# Altium schematic sheet MainBoard.SchDoc
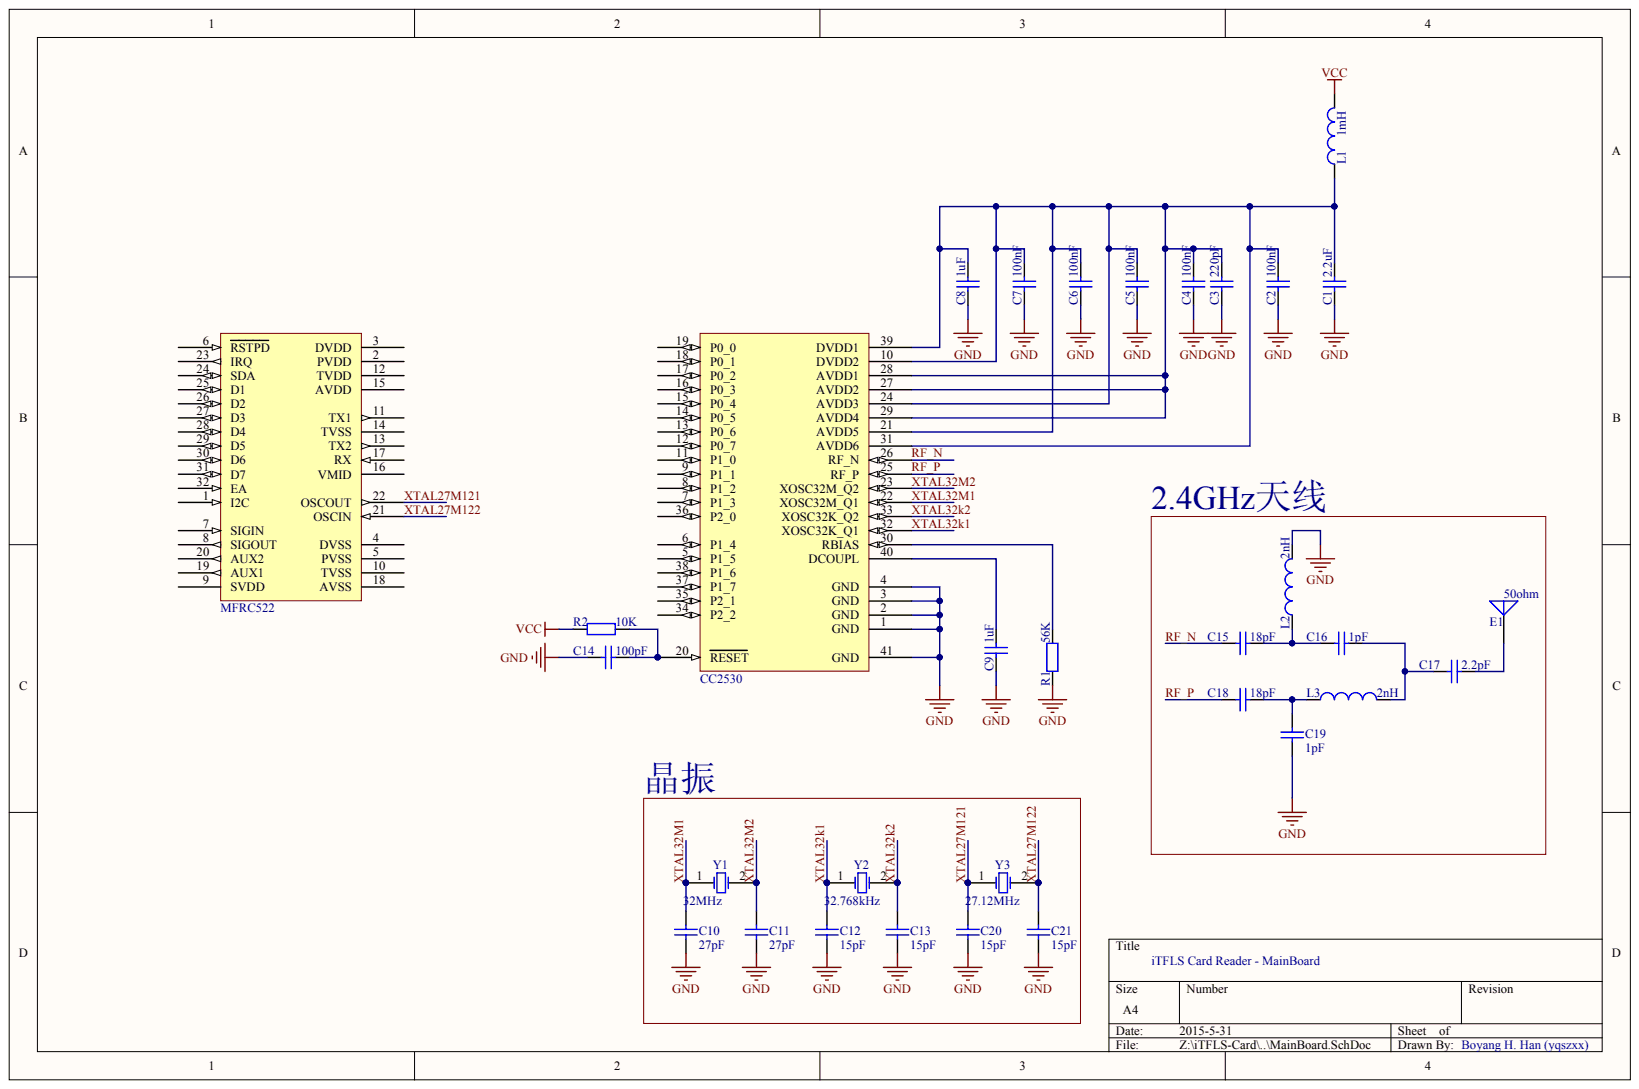
[diagram p0: MainBoard.SchDoc]

## Parts
1  CC2530
2  MFRC522
C1  value 2.2uF  [RAD-0.3]  (hidden comment: Cap)
C2  value 100nF  [RAD-0.3]  (hidden comment: Cap)
C3  value 220pF  [RAD-0.3]  (hidden comment: Cap)
C4  value 100nF  [RAD-0.3]  (hidden comment: Cap)
C5  value 100nF  [RAD-0.3]  (hidden comment: Cap)
C6  value 100nF  [RAD-0.3]  (hidden comment: Cap)
C7  value 100nF  [RAD-0.3]  (hidden comment: Cap)
C8  value 1uF  [RAD-0.3]  (hidden comment: Cap)
C9  value 1uF  [RAD-0.3]  (hidden comment: Cap)
C10  value 27pF  [RAD-0.3]  (hidden comment: Cap)
C11  value 27pF  [RAD-0.3]  (hidden comment: Cap)
C12  value 15pF  [RAD-0.3]  (hidden comment: Cap)
C13  value 15pF  [RAD-0.3]  (hidden comment: Cap)
C14  value 100pF  [RAD-0.3]  (hidden comment: Cap)
C15  value 18pF  [RAD-0.3]  (hidden comment: Cap)
C16  value 1pF  [RAD-0.3]  (hidden comment: Cap)
C17  value 2.2pF  [RAD-0.3]  (hidden comment: Cap)
C18  value 18pF  [RAD-0.3]  (hidden comment: Cap)
C19  value 1pF  [RAD-0.3]  (hidden comment: Cap)
C20  value 15pF  [RAD-0.3]  (hidden comment: Cap)
C21  value 15pF  [RAD-0.3]  (hidden comment: Cap)
E1  value 50ohm  [PIN1]  (hidden comment: Antenna)
L1  value 1mH  [0402-A]  (hidden comment: Inductor)
L2  value 2nH  [0402-A]  (hidden comment: Inductor)
L3  value 2nH  [0402-A]  (hidden comment: Inductor)
R1  value 56K  [AXIAL-0.4]  (hidden comment: Res2)
R2  value 10K  [AXIAL-0.4]  (hidden comment: Res2)
Y1  XTAL  value 32MHz  [R38]
Y2  XTAL  value 32.768kHz  [R38]
Y3  XTAL  value 27.12MHz  [R38]

## Nets
GND: 1.1(GND) 1.2(GND) 1.3(GND) 1.4(GND) 1.41(GND) C1.1 C2.1 C3.1 C4.1 C5.1 C6.1 C7.1 C8.1 C9.1 C10.1 C11.1 C12.1 C13.1 C14.1 C19.1 C20.1 C21.1 L2.2 R1.1
VCC: L1.2 R2.1
RF_N: 1.26(RF_N) C15.1
RF_P: 1.25(RF_P) C18.1
XTAL27M121: 2.22(OSCOUT) C20.2 Y3.1
XTAL27M122: 2.21(OSCIN) C21.2 Y3.2
XTAL32K1: 1.32(XOSC32K_Q1) C12.2 Y2.1
XTAL32K2: 1.33(XOSC32K_Q2) C13.2 Y2.2
XTAL32M1: 1.22(XOSC32M_Q1) C10.2 Y1.1
XTAL32M2: 1.23(XOSC32M_Q2) C11.2 Y1.2
Net1_10: 1.10(DVDD2) 1.21(AVDD5) 1.24(AVDD3) 1.27(AVDD2) 1.28(AVDD1) 1.29(AVDD4) 1.31(AVDD6) 1.39(DVDD1) C1.2 C2.2 C3.2 C4.2 C5.2 C6.2 C7.2 C8.2 L1.1
Net1_20: 1.20(RESET) C14.2 R2.2
Net1_30: 1.30(RBIAS) R1.2
Net1_40: 1.40(DCOUPL) C9.2
NetC15_2: C15.2 C16.1 L2.1
NetC16_2: C16.2 C17.1 L3.2
NetC17_2: C17.2 E1.1
NetC18_2: C18.2 C19.2 L3.1

Not connected: 1 5(P1_5) 6(P1_4) 7(P1_3) 8(P1_2) 9(P1_1) 11(P1_0) 12(P0_7) 13(P0_6) 14(P0_5) 15(P0_4) 16(P0_3) 17(P0_2) 18(P0_1) 19(P0_0) 34(P2_2) 35(P2_1) 36(P2_0) 37(P1_7) 38(P1_6); 2 1(I2C) 2(PVDD) 3(DVDD) 4(DVSS) 5(PVSS) 6(RSTPD) 7(SIGIN) 8(SIGOUT) 9(SVDD) 10(TVSS) 11(TX1) 12(TVDD) 13(TX2) 14(TVSS) 15(AVDD) 16(VMID) 17(RX) 18(AVSS) 19(AUX1) 20(AUX2) 23(IRQ) 24(SDA) 25(D1) 26(D2) 27(D3) 28(D4) 29(D5) 30(D6) 31(D7) 32(EA)

## Library data of the parts
C1-C21 = Cap: Capacitor; Component Kind: Standard; LatestRevisionDate: 17-Jul-2002; LatestRevisionNote: Re-released for DXP Platform.; Library Name: Miscellaneous Devices.IntLib; PackageDescription: Radial Cap, Thru-Hole; 2 Leads; 0.3 in Pin Spacing; PackageReference: RAD-0.3; Published: 8-Jun-2000; Signal Integrity: Cap; Simulation: CAP; Publisher: Altium Limited
E1 = Antenna: Generic Antenna; Component Kind: Standard; LatestRevisionDate: 17-Jul-2002; LatestRevisionNote: Re-released for DXP Platform.; Library Name: Miscellaneous Devices.IntLib; PackageReference: PIN1; Published: 24-Mar-1999; Publisher: Altium Limited
L1-L3 = Inductor: Code_IEC: 1005; Code_JEITA: 0402; Component Kind: Standard; Description: Inductor; LatestRevisionDate: 29-May-2009; LatestRevisionNote: IPC-7351 Footprint Added.; Library Name: Miscellaneous Devices.IntLib; PackageDescription: Chip Inductor; PackageReference: 0402-A; Published: 8-Jun-2000; Signal Integrity: Inductor; Simulation: INDUCTOR; Publisher: Altium Limited
R1 R2 = Res2: Resistor; Component Kind: Standard; LatestRevisionDate: 17-Jul-2002; LatestRevisionNote: Re-released for DXP Platform.; Library Name: Miscellaneous Devices.IntLib; PackageDescription: Axial Device, Thru-Hole; 2 Leads; 0.4 in Pin Spacing; PackageReference: AXIAL-0.4; Published: 8-Jun-2000; Signal Integrity: Res; Simulation: RESISTOR; Publisher: Altium Limited
Y1-Y3 = XTAL: Crystal Oscillator; Component Kind: Standard; LatestRevisionDate: 17-Jul-2002; LatestRevisionNote: Re-released for DXP Platform.; Library Name: Miscellaneous Devices.IntLib; PackageDescription: Crystal, Thru-Hole; 2 Leads; Body 3.1 x 8.2 mm (Dia.xH); PackageReference: R38; Published: 8-Jun-2000; Simulation: XTAL; Publisher: Altium Limited
1 = CC2530: Component Kind: Standard; Library Name: CC2530.SchLib
2 = MFRC522: Component Kind: Standard; Library Name: MFRC522.SchLib
